# Altium schematic sheet [6] Radio Frequency.SchDoc("[6] Radio Frequency")
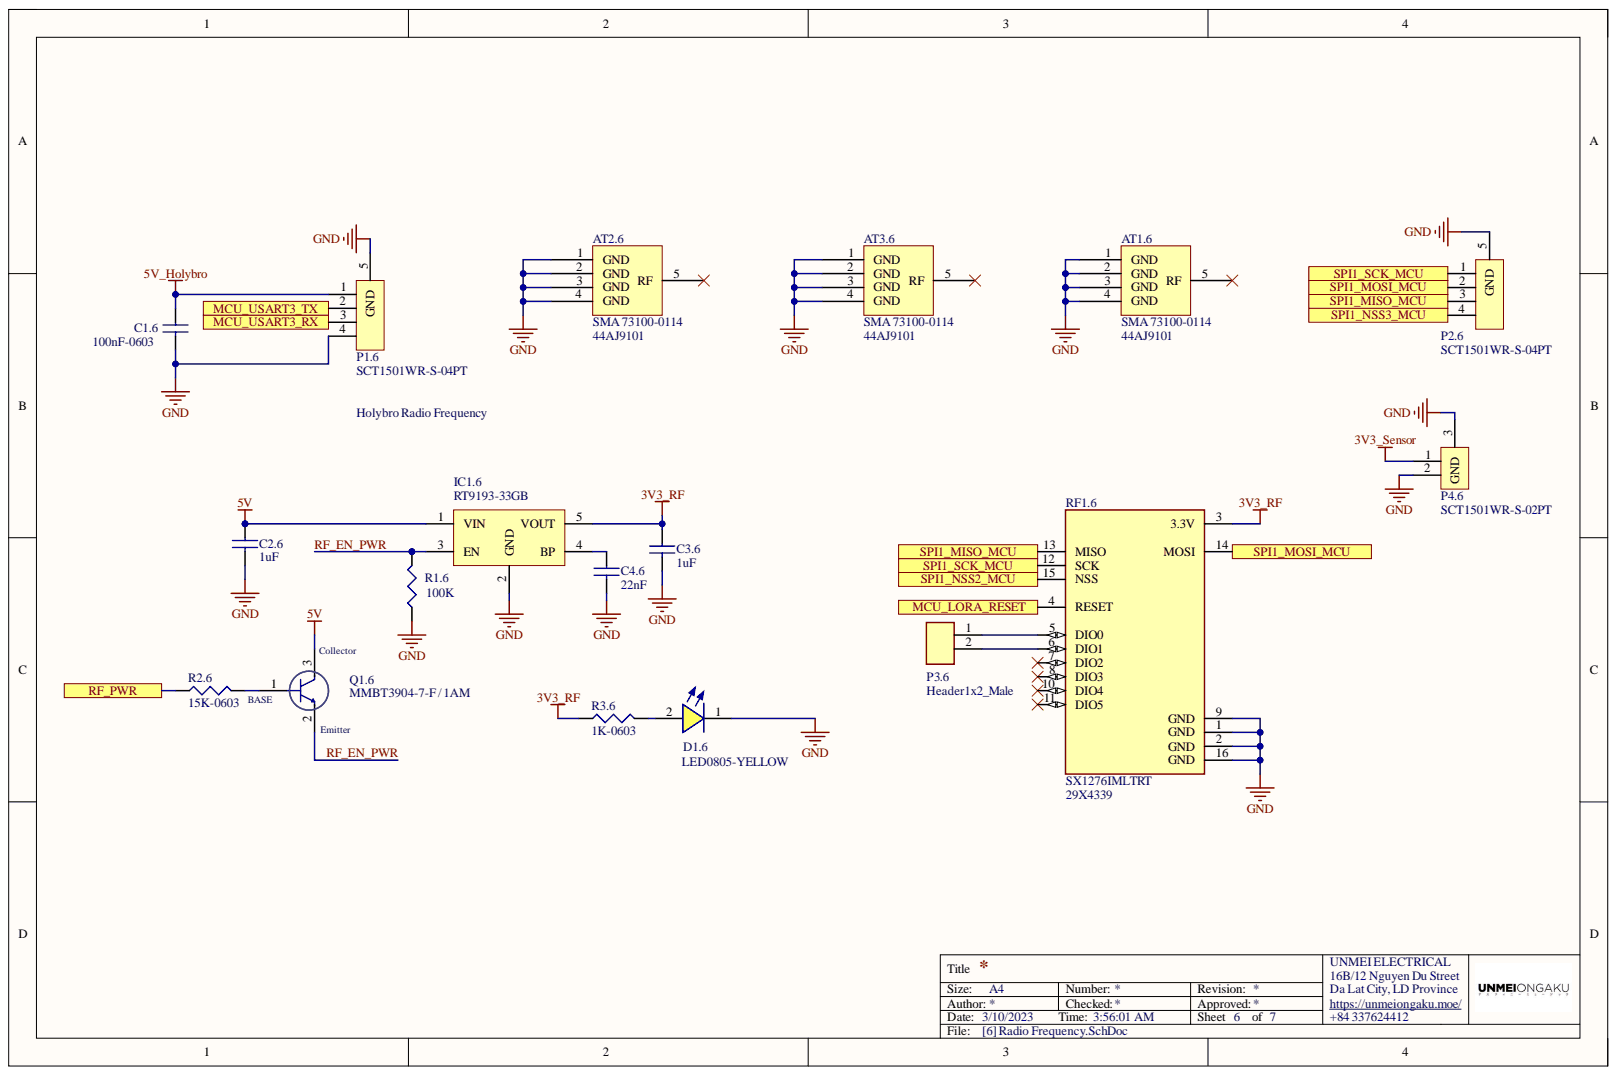
[diagram p0: [6] Radio Frequency.SchDoc]

## Parts
AT1.6  SMA 73100-0114  Case/Package: SMA  Manufacturer Part Number: 73100-0114  Supplier Part Number 1: 44AJ9101  [SMA Antenna Connection Jack]
AT2.6  SMA 73100-0114  Case/Package: SMA  Manufacturer Part Number: 73100-0114  Supplier Part Number 1: 44AJ9101  [SMA Antenna Connection Jack]
AT3.6  SMA 73100-0114  Case/Package: SMA  Manufacturer Part Number: 73100-0114  Supplier Part Number 1: 44AJ9101  [SMA Antenna Connection Jack]
C1.6  100nF-0603  [CAP0603]
C2.6  1uF  [CAP0603]
C3.6  1uF  [CAP0603]
C4.6  22nF  [CAP0603]
D1.6  LED0805-YELLOW  [LED0805-YELLOW]
IC1.6  RT9193-33GB  [SOT23-5]
P1.6  SCT1501WR-S-04PT  [Connector ZH1.5 4P SMD]
P2.6  SCT1501WR-S-04PT  [Connector ZH1.5 4P SMD]
P3.6  Header1x2_Male  [HDR1x2_2.54_MALE]
P4.6  SCT1501WR-S-02PT  [Connector ZH1.5 2P SMD]
Q1.6  MMBT3904-7-F / 1AM  Manufacturer Part Number: MMBT3904-7-F  [SOT23]
R1.6  100K  [RES0603 - 3D]
R2.6  15K-0603  [RES0603 - 3D]
R3.6  1K-0603  [RES0603 - 3D]
RF1.6  SX1276IMLTRT  Supplier Part Number 1: 29X4339  [Lora SX1278 433MHz  / Ai-Thinker Ra-02]

## Ports
MCU_LORA_RESET, MCU_USART3_RX, MCU_USART3_TX, RF_PWR, SPI1_MISO_MCU, SPI1_MOSI_MCU, SPI1_NSS2_MCU, SPI1_NSS3_MCU, SPI1_SCK_MCU

## Nets
3V3_RF: C3.6.1 IC1.6.5(VOUT) R3.6.1 RF1.6.3(3.3V)
3V3_Sensor: P4.6.1
5V: C2.6.1 IC1.6.1(VIN) Q1.6.3
5V_Holybro: C1.6.1 P1.6.1
GND: AT1.6.1(GND) AT1.6.2(GND) AT1.6.3(GND) AT1.6.4(GND) AT2.6.1(GND) AT2.6.2(GND) AT2.6.3(GND) AT2.6.4(GND) AT3.6.1(GND) AT3.6.2(GND) AT3.6.3(GND) AT3.6.4(GND) C1.6.2 C2.6.2 C3.6.2 C4.6.2 D1.6.1 IC1.6.2(GND) P1.6.4 P1.6.5(GND) P2.6.5(GND) P4.6.2 P4.6.3 R1.6.1 RF1.6.1(GND) RF1.6.2(GND) RF1.6.9(GND) RF1.6.16(GND)
MCU_LORA_RESET: RF1.6.4(RESET) ; port MCU_LORA_RESET
MCU_USART3_RX: P1.6.3 ; port MCU_USART3_RX
MCU_USART3_TX: P1.6.2 ; port MCU_USART3_TX
RF_EN_PWR: IC1.6.3(EN) Q1.6.2 R1.6.2
RF_PWR: R2.6.1 ; port RF_PWR
SPI1_MISO_MCU: P2.6.3 RF1.6.13(MISO) ; port SPI1_MISO_MCU
SPI1_MOSI_MCU: P2.6.2 RF1.6.14(MOSI) ; port SPI1_MOSI_MCU
SPI1_NSS2_MCU: RF1.6.15(NSS) ; port SPI1_NSS2_MCU
SPI1_NSS3_MCU: P2.6.4 ; port SPI1_NSS3_MCU
SPI1_SCK_MCU: P2.6.1 RF1.6.12(SCK) ; port SPI1_SCK_MCU
NetC4.6_1: C4.6.1 IC1.6.4(BP)
NetD1.6_2: D1.6.2 R3.6.2
NetP3.6_1: P3.6.1 RF1.6.5(DIO0)
NetP3.6_2: P3.6.2 RF1.6.6(DIO1)
NetQ1.6_1: Q1.6.1 R2.6.2

Not connected: AT1.6 5(RF); AT2.6 5(RF); AT3.6 5(RF); RF1.6 7(DIO2) 8(DIO3) 10(DIO4) 11(DIO5)

## Library data of the parts
AT1.6 AT2.6 AT3.6 = SMA 73100-0114: RF SMA Connector, 1 Contact(s), Female, Board Mount, Solder Terminal, Locking, Receptacle; Manufacturer: Molex; Body Material: Brass; Body Plating: Gold; Connector Type: PCB,SMA,Coaxial; Dielectric Material: PTFE; Frequency: 12Hz; Impedance: 50R; Max Frequency: 18GHz; Operating Frequency: 0 to 12Hz; Polarity: Normal; Voltage Rating: 500V; Category: RF / Coaxial Connectors; Center Contact Plating: Gold; Component Kind: Standard; Contact Material: Copper; Contact Plating: Gold; Fastening Type: Threaded; Gender: Female; Housing Color: Gold; Insulation Material: Teflon; Length: 14.8mm; Max Operating Temperature: 165°C; Min Operating Temperature: -65°C; Mount: Through Hole; Orientation: Right Angle; Package Quantity: 1760; Packaging: Tray; Plating: Gold; Pricing 1: 1=8.21000, 5=7.67000, 10=7.13000, 25=6.72000, 50=6.30000, 100=5.89000 (USD); Radiation Hardening: No; RoHS: Yes; RoHS Compliant: Yes; Series: 73100; Stock 1: 2371; Supplier 1: Newark; Termination: Solder; Library Name: Minh_Lib.SchLib
C1.6 = CC0603KRX7R9BB104: CAP CER 0.1UF 50V X7R 0603; Manufacturer 1: Yageo; Capacitance: 0.1µF; Category 1: Capacitors; Tolerance: ±10%; Value: 100nF; Applications: General Purpose; Component Kind: Standard; ComponentLink1Description: http://www.yageo.com/documents/recent/UPY-GPHC_X7R_6.3V-to-50V_18.pdf; ComponentLink1URL: http://www.yageo.com/documents/recent/UPY-GPHC_X7R_6.3V-to-50V_18.pdf; Description 1: CAP CER 0.1UF 50V X7R 0603; Failure Rate: -; Features: -; Height - Seated (Max): -; Lead Spacing: -; Lead Style: -; Manufacturer Part Number 1: CC0603KRX7R9BB104; Mounting Type: Surface Mount, MLCC; Operating Temperature: -55°C ~ 125°C; Package / Case: 0603 (1608 Metric); Packaging: Cut Tape (CT); Part Status: Active; Pricing 1: 1=0.1, 10=0.031, 100=0.014, 500=0.00998, 1000=0.00783 (USD); Ratings: -; RoHS 1: RoHS Compliant; Size / Dimension: 0.063" L x 0.031" W (1.60mm x 0.80mm); Stock 1: 4411645; Supplier 1: Digi-Key; Supplier Part Number 1: 311-1344-1-ND; Temperature Coefficient: X7R; Thickness (Max): 0.035" (0.90mm); Voltage - Rated: 50V; Library Name: Minh_Lib.SchLib
C2.6 C3.6 = CC0603KRX7R7BB105: CAP CER 1UF 16V X7R 0603; Manufacturer 1: Yageo; Capacitance: 1µF; Category 1: Capacitors; Tolerance: ±10%; Applications: General Purpose; Component Kind: Standard; ComponentLink1Description: http://www.yageo.com/documents/recent/UPY-GPHC_X7R_6.3V-to-50V_18.pdf; ComponentLink1URL: http://www.yageo.com/documents/recent/UPY-GPHC_X7R_6.3V-to-50V_18.pdf; Description 1: CAP CER 1UF 16V X7R 0603; Failure Rate: -; Features: -; Height - Seated (Max): -; Lead Spacing: -; Lead Style: -; Manufacturer Part Number 1: CC0603KRX7R7BB105; Mounting Type: Surface Mount, MLCC; Operating Temperature: -55°C ~ 125°C; Package / Case: 0603 (1608 Metric); Packaging: Cut Tape (CT); Part Status: Active; Pricing 1: 1=0.1, 10=0.051, 100=0.0227, 500=0.0162, 1000=0.01274 (USD); Ratings: -; RoHS 1: RoHS Compliant; Size / Dimension: 0.063" L x 0.031" W (1.60mm x 0.80mm); Stock 1: 274580; Supplier 1: Digi-Key; Supplier Part Number 1: 311-1446-1-ND; Temperature Coefficient: X7R; Thickness (Max): 0.035" (0.90mm); Value: 1uF; Voltage - Rated: 16V; Library Name: Minh_Lib.SchLib
C4.6 = CC0603KRX7R9BB223: Multilayer Ceramic Capacitors MLCC - SMD/SMT 22nF 50V X7R 10%; Brand: Yageo; Capacitance: 0.022 uF; Capacitance - pF: 22000 pF; Class: Class 2; Type: General Purpose and High Capacitance Surface Mount Ceramic Multilayer Capacitor; Voltage Rating DC: 50 VDC; Capacitance - nF: 22 nF; Case Code - in: 0603; Case Code - mm: 1608; Category: Multilayer Ceramic Capacitors MLCC - SMD/SMT; Component Kind: Standard; ComponentLink1Description: https://www.mouser.com/datasheet/2/447/UPY-GPHC_X7R_6.3V-to-50V_18-1154002.pdf; ComponentLink1URL: https://www.mouser.com/datasheet/2/447/UPY-GPHC_X7R_6.3V-to-50V_18-1154002.pdf; Dielectric: X7R; Height: 0.8 mm; Length: 1.6 mm; Manufacturer: Yageo; Manufacturer Part Number: CC0603KRX7R9BB223; Maximum Operating Temperature: + 125 C; Minimum Operating Temperature: - 55 C; Minimum Order Quantity: 1; Package / Case: 0603 (1608 metric); Packaging: Cut Tape; Pricing 1: 1=0.100, 10=0.064, 100=0.020, 1000=0.015, 4000=0.011, 8000=0.010, 24000=0.009 (USD); Product: General Type MLCCs; Product Type: Ceramic Capacitors; Reeling: True; RoHS: RoHS Compliant; RoHS - Mouser: Y; Series: CC; Standard Pack Qty: 4000; Stock 1: 23033; Subcategory: Capacitors; Supplier 1: Mouser; Supplier Part Number 1: 603-CC603KRX7R9BB223; Termination: Standard; Termination Style: SMD/SMT; Tolerance: 10 %; Value: 22nF; Width: 0.8 mm; Library Name: Minh_Lib.SchLib
IC1.6 = RT9193-33GB: IC REG LDO 3.3V 0.3A SOT23-5; Component Kind: Standard; Library Name: Minh_Lib.SchLib
P3.6 = HDR1x2_2.54_MALE: Header1x2_2.54_MALE; Component Kind: Standard; Library Name: Minh_Lib.SchLib
Q1.6 = MMBT3904-7-F / 1AM: Transistor General Purpose BJT NPN 40 Volt 0.2A Automotive 3-Pin SOT-23; Manufacturer: Diodes Zetex; Collector Base Voltage (VCBO): 60V; Collector Emitter Breakdown Voltage: 40V; Collector Emitter Saturation Voltage: 300mV; Continuous Collector Current: 200mA; Element Configuration: Single; Emitter Base Voltage (VEBO): 6V; Frequency: 300MHz; hFE Min: 100; Max Power Dissipation: 300mW; Number of Elements: 1; Power Dissipation: 350mW; Case/Package: SOT-23; Category: BJTs; Collector Emitter Voltage (VCEO): 40V; Collector-emitter Voltage-Max: 300mV; Component Kind: Standard; ComponentLink1Description: https://datasheet.ciiva.com/11201/ds30036-11201778.pdf?src-supplier=Avnet; ComponentLink1URL: https://datasheet.ciiva.com/11201/ds30036-11201778.pdf?src-supplier=Avnet; ComponentLink2Description: https://datasheet.ciiva.com/15138/getdatasheetpartid-53265-15138780.pdf?src-supplier=Verical; ComponentLink2URL: https://datasheet.ciiva.com/15138/getdatasheetpartid-53265-15138780.pdf?src-supplier=Verical; ComponentLink3Description: https://datasheet.ciiva.com/22711/1915516-22711750.pdf?src-supplier=Element14; ComponentLink3URL: https://datasheet.ciiva.com/22711/1915516-22711750.pdf?src-supplier=Element14; ComponentLink4Description: https://datasheet.ciiva.com/11198/ds30036-11198999.pdf?src-supplier=Avnet; ComponentLink4URL: https://datasheet.ciiva.com/11198/ds30036-11198999.pdf?src-supplier=Avnet; ComponentLink5Description: https://datasheet.ciiva.com/6361/ds30036-6361256.pdf?src-supplier=Digi-Key; ComponentLink5URL: https://datasheet.ciiva.com/6361/ds30036-6361256.pdf?src-supplier=Digi-Key; ComponentLink6Description: https://datasheet.ciiva.com/6361/ds30036-6361254.pdf?src-supplier=Digi-Key; ComponentLink6URL: https://datasheet.ciiva.com/6361/ds30036-6361254.pdf?src-supplier=Digi-Key; Contact Plating: Tin,Matte; Gain Bandwidth Product: 300MHz; Height: 1mm; Lead Free: Lead Free; Length: 3.05mm; Max Breakdown Voltage: 40V; Max Collector Current: 200mA; Max Frequency: 300MHz; Max Operating Temperature: 150°C; Min Operating Temperature: -55°C; Mount: Surface Mount; Number of Pins: 3; Package Quantity: 3000; Packaging: Tape and Reel; Polarity: NPN; Pricing 1: 3000=0.02271, 6000=0.02048, 15000=0.01781, 30000=0.01603, 75000=0.01425 (USD); Radiation Hardening: No; REACH SVHC: No SVHC; RoHS: Yes; RoHS Compliant: Yes; Series: -; Stock 1: 468030; Supplier 1: Digi-Key; Supplier Part Number 1: MMBT3904-FDITR-ND; Transition Frequency: 300MHz; Voltage Rating (DC): 40V; Weight: 0.000282oz; Width: 1.4mm; Library Name: Minh_Lib.SchLib
R1.6 = RC0603JR-07100KL: RES SMD 100K OHM 5% 1/10W 0603; Manufacturer 1: Yageo; Category 1: Resistors; Power (Watts): 0.1W, 1/10W; Temperature Coefficient: ±100ppm/°C; Component Kind: Standard; ComponentLink1Description: http://www.yageo.com/documents/recent/PYu-RC_Group_51_RoHS_L_7.pdf; ComponentLink1URL: http://www.yageo.com/documents/recent/PYu-RC_Group_51_RoHS_L_7.pdf; Composition: Thick Film; Description 1: RES SMD 100K OHM 5% 1/10W 0603; Failure Rate: -; Features: Moisture Resistant; Height - Seated (Max): 0.022" (0.55mm); Manufacturer Part Number 1: RC0603JR-07100KL; Operating Temperature: -55°C ~ 155°C; Package / Case: 0603 (1608 Metric); Packaging: Cut Tape (CT); Part Status: Active; Pricing 1: 1=0.1, 10=0.011, 100=0.0044, 1000=0.00198, 2500=0.00172 (USD); Resistance (Ohms): 100k; RoHS 1: RoHS Compliant; Size / Dimension: 0.063" L x 0.031" W (1.60mm x 0.80mm); Stock 1: 3591837; Supplier 1: Digi-Key; Supplier Device Package: 0603; Supplier Part Number 1: 311-100KGRCT-ND; Tolerance: ±5%; Value: 100K; Library Name: Minh_Lib.SchLib
R2.6 = RC0603JR-0715KL: Thick Film Resistors - SMD 15K OHM 5%; Brand: Yageo; Power Rating: 100 mW, 200 mW; Resistance: 15 kOhms; Temperature Coefficient: 100 PPM / C; Voltage Rating: 75 V; Application: High Reliability; Case Code - in: 0603; Case Code - mm: 1608; Category: Thick Film Resistors - SMD; Component Kind: Standard; ComponentLink1Description: http://www.mouser.com/datasheet/2/447/PYu-RC_Group_51_RoHS_L_9-1314892.pdf; ComponentLink1URL: http://www.mouser.com/datasheet/2/447/PYu-RC_Group_51_RoHS_L_9-1314892.pdf; Features: -; Height: 0.45 mm; Length: 1.6 mm; Manufacturer: Yageo; Manufacturer Part Number: RC0603JR-0715KL; Maximum Operating Temperature: + 155 C; Minimum Operating Temperature: - 55 C; Minimum Order Quantity: 1; Mounting Style: PCB Mount; Package / Case: 0603 (1608 metric); Packaging: Cut Tape; Pricing 1: 1=0.100, 10=0.015, 100=0.005, 1000=0.004, 5000=0.004 (USD); Product: Thick Film Resistors SMD; Product Type: Thick Film Resistors; Reeling: True; RoHS: RoHS Compliant; RoHS - Mouser: Y; Series: RC; Standard Pack Qty: 5000; Stock 1: 39889; Subcategory: Resistors; Supplier 1: Mouser; Supplier Part Number 1: 603-RC0603JR-0715KL; Technology: Thick Film; Termination Style: SMD/SMT; Tolerance: 5 %; Type: General Purpose; Value: 15K; Width: 0.8 mm; Library Name: Minh_Lib.SchLib
R3.6 = RC0603JR-071KL: RES SMD 1K OHM 5% 1/10W 0603; Manufacturer 1: Yageo; Category 1: Resistors; Power (Watts): 0.1W, 1/10W; Temperature Coefficient: ±100ppm/°C; Component Kind: Standard; ComponentLink1Description: http://www.yageo.com/documents/recent/PYu-RC_Group_51_RoHS_L_7.pdf; ComponentLink1URL: http://www.yageo.com/documents/recent/PYu-RC_Group_51_RoHS_L_7.pdf; Composition: Thick Film; Description 1: RES SMD 1K OHM 5% 1/10W 0603; Failure Rate: -; Features: Moisture Resistant; Height - Seated (Max): 0.022" (0.55mm); Manufacturer Part Number 1: RC0603JR-071KL; Operating Temperature: -55°C ~ 155°C; Package / Case: 0603 (1608 Metric); Packaging: Cut Tape (CT); Part Status: Active; Pricing 1: 1=0.1, 10=0.011, 100=0.0044, 1000=0.00198, 2500=0.00172 (USD); Resistance (Ohms): 1k; RoHS 1: RoHS Compliant; Size / Dimension: 0.063" L x 0.031" W (1.60mm x 0.80mm); Stock 1: 2853955; Supplier 1: Digi-Key; Supplier Device Package: 0603; Supplier Part Number 1: 311-1.0KGRCT-ND; Tolerance: ±5%; Value: 1K; Library Name: Minh_Lib.SchLib
RF1.6 = SX1276IMLTRT: Rf Ism Transceiver Fsk/gfsk/gmsk/msk/ook 3.3V Automotive 28-PIN QFN Ep T/r; Manufacturer: Semtech; Current - Receiving: 12mA; Current - Transmitting: 120mA; Data Rate: 300Kbps; Frequency: 137MHz; Interface: SPI; Number of GPIO: 6; Operating Supply Voltage: 3.7V; Sensitivity: -148dBm; Case/Package: VQFN; Category: RF Receivers, Transceivers; Component Kind: Standard; ComponentLink1Description: https://datasheet.ciiva.com/29635/sx1276-29635606.pdf?src-supplier=Digi-Key; ComponentLink1URL: https://datasheet.ciiva.com/29635/sx1276-29635606.pdf?src-supplier=Digi-Key; ComponentLink2Description: https://datasheet.ciiva.com/29635/sx1276-29635607.pdf?src-supplier=Digi-Key; ComponentLink2URL: https://datasheet.ciiva.com/29635/sx1276-29635607.pdf?src-supplier=Digi-Key; ComponentLink3Description: https://datasheet.ciiva.com/29635/sx1276-29635605.pdf?src-supplier=Digi-Key; ComponentLink3URL: https://datasheet.ciiva.com/29635/sx1276-29635605.pdf?src-supplier=Digi-Key; Lead Free: Lead Free; Manufacturer Part Number: SX1276IMLTRT; Max Operating Temperature: 85°C; Min Operating Temperature: -40°C; Package Quantity: 3000; Packaging: Tape and Reel; Pricing 1: 1=9.90000, 10=9.04000, 25=8.28000, 50=7.88000, 100=7.47000, 250=6.87000, 500=6.24000 (USD); RoHS: Yes; RoHS Compliant: Yes; Stock 1: 2848; Supplier 1: Newark; Library Name: Minh_Lib.SchLib
D1.6 = LED0805-YELLOW: Component Kind: Standard; Library Name: Minh_Lib.SchLib
P1.6 P2.6 = SCT1501WR-S-04PT: Component Kind: Standard; Library Name: Minh_Lib.SchLib
P4.6 = SCT1501WR-S-02PT: Component Kind: Standard; Library Name: Minh_Lib.SchLib
